# Altium schematic sheet LoraModulos.SchDoc
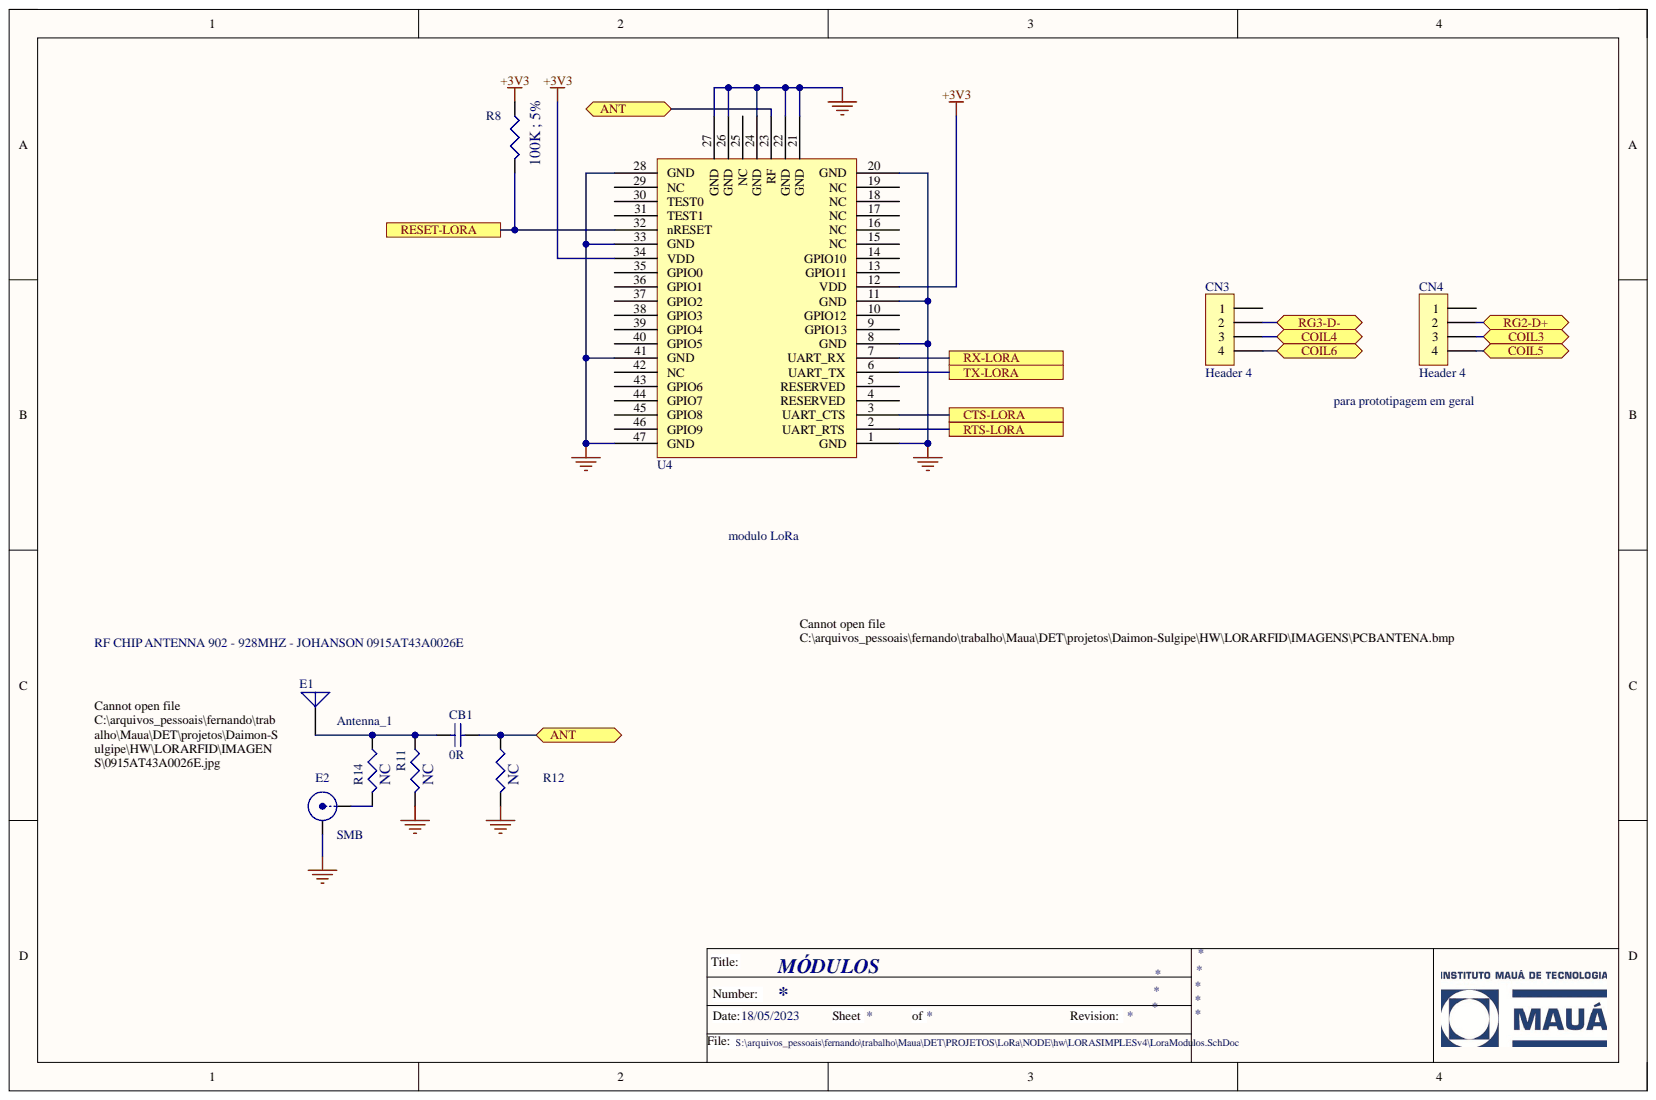
[diagram p0: LoraModulos.SchDoc]

## Parts
CB1  value 0R  [0402]  (hidden comment: 02.0404)
CN3  Header 4  [HDR1X4]
CN4  Header 4  [HDR1X4]
E1  Antenna_1  [HADA31 TAOGLAS]
E2  SMB  [SMB_V-RJ45]
R8  Valor: 100K ; 5%  [0603]
R11  Valor: NC  [0402]
R12  Valor: NC  [0402]
R14  Valor: NC  [0402]
U4  (no value)  [RN2903]

## Ports
ANT, COIL3, COIL4, COIL5, COIL6, CTS-LORA, RESET-LORA, RG2-D+, RG3-D-, RTS-LORA, RX-LORA, TX-LORA

## Nets
+3V3: R8.1 U4.12(VDD) U4.34(VDD)
GND: E2.2 R11.2 R12.2 U4.1(GND) U4.8(GND) U4.11(GND) U4.20(GND) U4.21(GND) U4.22(GND) U4.24(GND) U4.26(GND) U4.27(GND) U4.28(GND) U4.33(GND) U4.41(GND) U4.47(GND)
ANT: CB1.1 R12.1 U4.23(RF) ; port ANT
COIL3: CN4.3 ; port COIL3
COIL4: CN3.3 ; port COIL4
COIL5: CN4.4 ; port COIL5
COIL6: CN3.4 ; port COIL6
CTS-LORA: U4.3(UART_CTS) ; port CTS-LORA
RESET-LORA: R8.2 U4.32(nRESET) ; port RESET-LORA
RG2-D+: CN4.2 ; port RG2-D+
RG3-D-: CN3.2 ; port RG3-D-
RTS-LORA: U4.2(UART_RTS) ; port RTS-LORA
RX-LORA: U4.7(UART_RX) ; port RX-LORA
TX-LORA: U4.6(UART_TX) ; port TX-LORA
NetCB1_2: CB1.2 E1.1 R11.1 R14.1
NetE2_1: E2.1 R14.2

Not connected: CN3 1; CN4 1; U4 4(RESERVED) 5(RESERVED) 9(GPIO13) 10(GPIO12) 13(GPIO11) 14(GPIO10) 15(NC) 16(NC) 17(NC) 18(NC) 19(NC) 25(NC) 29(NC) 30(TEST0) 31(TEST1) 35(GPIO0) 36(GPIO1) 37(GPIO2) 38(GPIO3) 39(GPIO4) 40(GPIO5) 42(NC) 43(GPIO6) 44(GPIO7) 45(GPIO8) 46(GPIO9)

## Library data of the parts
CB1 = Capacitor Cerâmico SMD: Component Kind: Standard; Description: Capacitor Cerâmico SMD; LatestRevisionDate: 17-Jul-2002; LatestRevisionNote: Re-released for DXP Platform.; Library Name: Miscellaneous Devices.IntLib; Published: 8-Jun-2000; Publisher: Altium Limited
CN3 CN4 = Header 4: Header, 4-Pin; Component Kind: Standard; LatestRevisionDate: 17-Jul-2002; LatestRevisionNote: Re-released for DXP Platform.; Library Name: Miscellaneous Connectors.IntLib; Signal Integrity: Connector; Publisher: Altium Limited
E1 = Antenna_1: Antenna PCI LoRa; Component Kind: Standard; LatestRevisionDate: 17-Jul-2002; LatestRevisionNote: Re-released for DXP Platform.; Library Name: Miscellaneous Devices.IntLib; PackageReference: PIN1; Published: 24-Mar-1999; Signal Integrity: Guessed Model; Publisher: Altium Limited
E2 = SMB: SMB Straight Connector; Component Kind: Standard; LatestRevisionDate: 17-Jul-2002; LatestRevisionNote: Re-released for DXP Platform.; Library Name: Miscellaneous Connectors.IntLib; Signal Integrity: Connector; Publisher: Altium Limited
R8 = Res3: Resistor; Encapsulamento: 0402; Grupo: 01; Component Kind: Standard; LatestRevisionDate: 04-Mar-2005; LatestRevisionNote: PCB Footprint replaced.; Library Name: Miscellaneous Devices.IntLib; PackageReference: 0402; Published: 8-Jun-2000; Signal Integrity: Res; Simulation: RESISTOR; Publisher: Altium Limited
R11 R12 R14 = Res3: Resistor; Grupo: 01; Component Kind: Standard; Encapsulamento: 0402; LatestRevisionDate: 04-Mar-2005; LatestRevisionNote: PCB Footprint replaced.; Library Name: Miscellaneous Devices.IntLib; PackageReference: 0402; Published: 8-Jun-2000; Signal Integrity: Res; Simulation: RESISTOR; Publisher: Altium Limited
U4 = RN2903: LORA Transceiver Module; Fabricante: Microchip; Grupo: 07; Component Kind: Standard; Datasheet: http://ww1.microchip.com/downloads/en/DeviceDoc/50002390B.pdf; Library Name: RF MICROCHIP.IntLib; Signal Integrity: Guessed Model; Valor: RN2903
